# Altium schematic sheet TSAL.SchDoc(TSAL)
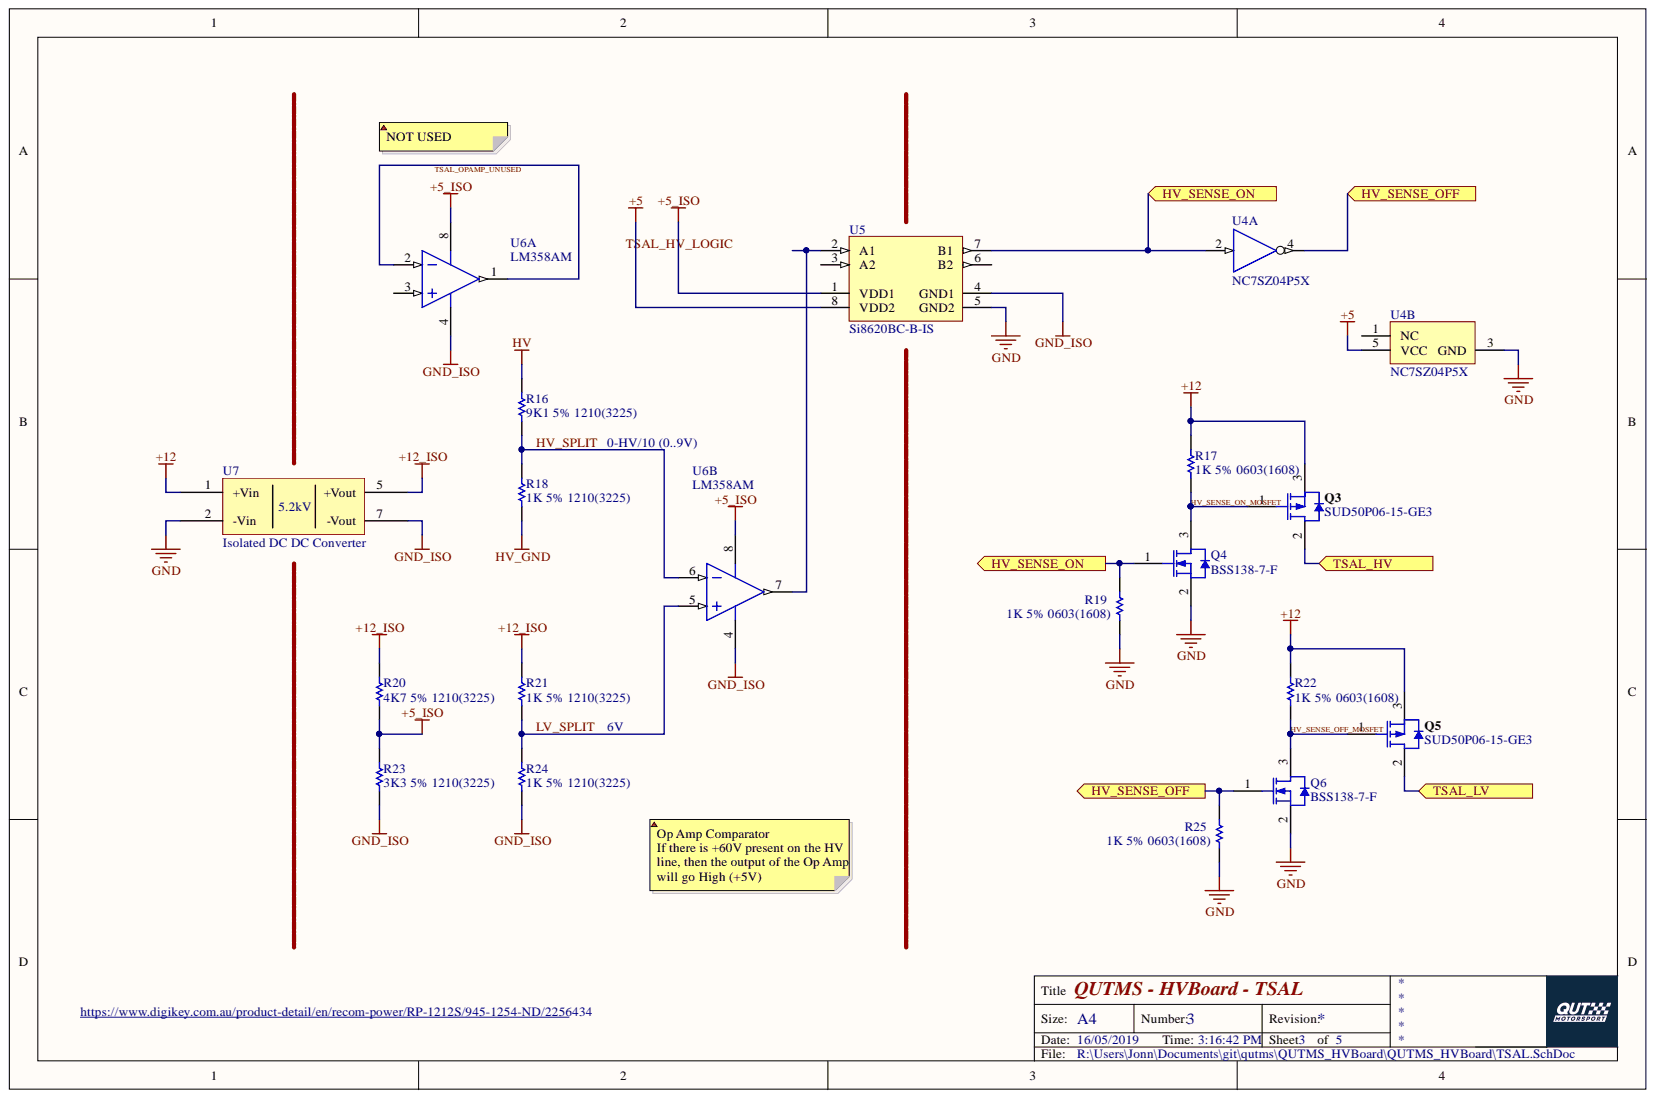
[diagram p0: TSAL.SchDoc]

## Parts
Q3  SUD50P06-15-GE3  [VSIL-TO-252AA-3_V]
Q4  BSS138-7-F  [DIOD-SOT23-3_V]
Q5  SUD50P06-15-GE3  [VSIL-TO-252AA-3_V]
Q6  BSS138-7-F  [DIOD-SOT23-3_V]
R16  9K1 5% 1210(3225)  [RESC1210(3225)_N]
R17  1K 5% 0603(1608)  [RESC0603(1608)_L]
R18  1K 5% 1210(3225)  [RESC1210(3225)_N]
R19  1K 5% 0603(1608)  [RESC0603(1608)_L]
R20  4K7 5% 1210(3225)  [RESC1210(3225)_N]
R21  1K 5% 1210(3225)  [RESC1210(3225)_N]
R22  1K 5% 0603(1608)  [RESC0603(1608)_L]
R23  3K3 5% 1210(3225)  [RESC1210(3225)_N]
R24  1K 5% 1210(3225)  [RESC1210(3225)_N]
R25  1K 5% 0603(1608)  [RESC0603(1608)_L]
U4  NC7SZ04P5X  [FAIR-SC70-5_V]  (parts A,B)
U5  Si8620BC-B-IS  [SLAB-SOIC-8-NB_M]
U6  LM358AM  [D0008A_N]  (parts A,B)
U7  Isolated DC DC Converter  [RP1212S]

## Ports
HV_SENSE_OFF, HV_SENSE_ON, TSAL_HV, TSAL_LV

## Nets
+5: U4.5(VCC) U5.8(VDD2)
+5_ISO: R20.1 R23.2 U5.1(VDD1) U6.8
+12: Q3.3 Q5.3 R17.2 R22.2 U7.1(+Vin)
+12_ISO: R20.2 R21.2 U7.5(+Vout)
GND: Q4.2 Q6.2 R19.1 R25.1 U4.3(GND) U5.5(GND2) U7.2(-Vin)
GND_ISO: R23.1 R24.1 U5.4(GND1) U6.4 U7.7(-Vout)
HV: R16.2
HV_GND: R18.1
HV_SENSE_OFF: Q6.1 R25.2 U4.4 ; port HV_SENSE_OFF
HV_SENSE_OFF_MOSFET: Q5.1 Q6.3 R22.1
HV_SENSE_ON: Q4.1 R19.2 U4.2 U5.7(B1) ; port HV_SENSE_ON
HV_SENSE_ON_MOSFET: Q3.1 Q4.3 R17.1
HV_SPLIT: R16.1 R18.2 U6.6
LV_SPLIT: R21.1 R24.2 U6.5
TSAL_HV: Q3.2 ; port TSAL_HV
TSAL_HV_LOGIC: U5.2(A1) U6.7
TSAL_LV: Q5.2 ; port TSAL_LV
TSAL_OPAMP_UNUSED: U6.1 U6.2

Not connected: U4 1(NC); U5 3(A2) 6(B2); U6 3

## Library data of the parts
Q3 Q5 = CMP-2000-05431-1: P-Channel (D-S) MOSFET, 30 V, -55 to 150 degC, 3-Pin TO-252, RoHS, Tape and Reel; Manufacturer: Vishay; Vgs - Gate-Source Voltage: 20V; Vds - Drain-Source Breakdown Voltage: -60V; Unit Weight: 0.050717oz; Typical Turn-On Delay Time: 15ns; Typical Turn-Off Delay Time: 175ns; Transistor Type: 1P-Channel; Rise Time: 70ns; Rds On - Drain-Source Resistance: 15mOhms; Pd - Power Dissipation: 2.5W; Number of Channels: 1Channel; Id - Continuous Drain Current: 50A; Configuration: Single; Channel Mode: Enhancement; Brand: VishaySemiconductors; Width: 6.22mm; Transistor Polarity: P-Channel; Tradename: TrenchFET; Technology: Si; Series: SUD; RoHS: True; Product Category: MOSFET; Packaging: Reel; Package URL: http://www.vishay.com/docs/89975/v15wl45c-m3.pdf; Package Reference: TO-252; Package Description: 3-Pin Surface Mount Device, Body 6.54 x 6.095 mm, Pitch 2.28 mm; Package / Case: TO-252AA-3; Mounting Style: SMD/SMT; Minimum Operating Temperature: -55C; Maximum Operating Temperature: +150C; Manufacturer URL: http://www.vishay.com/; Library Name: Altium Content Vault; Length: 6.73mm; Height: 2.39mm; Fall Time: 175ns; Factory Pack Quantity: 2000; Datasheet Version: Rev. C, 07/2013; Datasheet URL: https://datasheet.ciiva.com/4444/sud50p06-244530-4444327.pdf; Component Kind: Standard
Q4 Q6 = CMP-2000-04930-1: N-Channel Enhancement Mode Mosfet, 50 V, 0.2 A, -55 to 150 degC, 3-Pin SOT23, RoHS, Tape and Reel; Manufacturer: Diodes Inc; Vgs - Gate-Source Voltage: 20V; Vds - Drain-Source Breakdown Voltage: 50V; Unit Weight: 0.000282oz; Typical Turn-On Delay Time: 20ns; Transistor Type: 1N-Channel; Rds On - Drain-Source Resistance: 3.5Ohms; Pd - Power Dissipation: 300mW; Number of Channels: 1Channel; Id - Continuous Drain Current: 200mA; Configuration: Single; Brand: DiodesIncorporated; Width: 1.3mm; Typical Turn-Off Delay Time: 20ns; Transistor Polarity: N-Channel; Technology: Si; Series: BSS138; RoHS: True; Product Category: MOSFET; Product: MOSFETSmallSignal; Packaging: Reel; Package Reference: SOT23-3; Package Description: 3-Pin Small Outline Transistor, Body 2.9 x 1.3 mm, Pitch 0.96 mm; Package / Case: SOT-23-3; Mounting Style: SMD/SMT; Minimum Operating Temperature: -55C; Maximum Operating Temperature: +150C; Manufacturer URL: http://www.diodes.com/; Library Name: Altium Content Vault; Length: 2.9mm; Height: 1mm; Factory Pack Quantity: 3000; Datasheet Version: Rev. 20-2, 11/2013; Datasheet URL: https://datasheet.ciiva.com/8115/ds30144-8115344.pdf; Component Kind: Standard; Channel Mode: Enhancement
R16 = CMP-1015-00097-1: 9K1 0.5W 5% 1210 (3225 Metric)  SMD; Value: 9100.00 Ohm; Case-Metric: 3225; Case-EIA: 1210; Tolerance: 5 %; Technology: SMT; Power: 0.5 W; Library Name: Altium Content Vault; Component Kind: Standard
R17 R19 R22 R25 = CMP-1012-00074-1: 1K 0.1W 5% 0603 (1608 Metric)  SMD; Value: 1000.00 Ohm; Case-Metric: 1608; Case-EIA: 0603; Tolerance: 5 %; Technology: SMT; Power: 0.1 W; Library Name: Altium Content Vault; Component Kind: Standard
R18 R21 R24 = CMP-1015-00074-1: 1K 0.5W 5% 1210 (3225 Metric)  SMD; Value: 1000.00 Ohm; Case-Metric: 3225; Case-EIA: 1210; Tolerance: 5 %; Technology: SMT; Power: 0.5 W; Library Name: Altium Content Vault; Component Kind: Standard
R20 = CMP-1015-00090-1: 4K7 0.5W 5% 1210 (3225 Metric)  SMD; Value: 4700.00 Ohm; Case-Metric: 3225; Case-EIA: 1210; Tolerance: 5 %; Technology: SMT; Power: 0.5 W; Library Name: Altium Content Vault; Component Kind: Standard
R23 = CMP-1015-00086-1: 3K3 0.5W 5% 1210 (3225 Metric)  SMD; Value: 3300.00 Ohm; Case-Metric: 3225; Case-EIA: 1210; Tolerance: 5 %; Technology: SMT; Power: 0.5 W; Library Name: Altium Content Vault; Component Kind: Standard
U4 = CMP-2000-06814-1: TinyLogic(R) UHS Inverter, 32 mA, 1.65 to 5.5 V, -40 to 85 degC, 5-Pin SOT23 (SC70), RoHS, Tape and Reel; Manufacturer: FairchildSemiconductor; Typical Operating Supply Voltage: 1.8; Rad Hard: No; Propagation Delay Test Condition: 50pF; Number of Elements per Chip: 1; Maximum Propagation Delay Time @ Maximum CL: 5@3.3V; Maximum Low Level Output Current: 32mA; Maximum High Level Output Current: -32mA; Logic Family: TinyLogicUHS; Fabrication Technology: CMOS; Packaging: Tape&Reel; Package URL: https://www.fairchildsemi.com/package-drawings/MA/MAA05A.pdf; Package Reference: SC70-5; Package Description: 5-Pin Small Outline Transistor, Body 2 x 1.25 mm, Pitch 0.65 mm; Package: 5SC-70; Operating Temperature: -40to85°C; Mounting Technology: SurfaceMount; Manufacturer URL: https://www.fairchildsemi.com/; Logic Function: Inverter; Library Name: Altium Content Vault; Datasheet Version: Rev. 1.0.5, 02/2011; Datasheet URL: https://www.fairchildsemi.com/datasheets/NC/NC7SZ04.pdf; Component Kind: Standard; Category: InverterSchmittTrigger
U5 = CMP-0512-00372-1: Low-Power Single and Dual-Channel Digital Isolator, 150 Mbps, 3.75 kVRMS Isolation Rating, -40 to 125 degC, 8-pin SOIC; Manufacturer: Silicon Labs; Sub-Family: Isolators; Maximum Propagation Delay (ns): 13; Maximum Data Rate (Mbps): 150; Isolation Rating (kV): 3.75; Enable Control: FALSE; Channel Type: Unidirectional; AEC-Q100: TRUE; PartNumber: Si8620BC-B-IS; PackageReference: SOIC-8-NB; PackageDescription: 8-Pin Narrow Body Plastic Small Outline, Body 4.9 x 3.9 mm, Pitch 1.27 mm; Mounting Technology: Surface Mount; Library Name: Altium Content Vault; DatasheetVersion: Rev. 1.3; ComponentLink3URL: http://www.silabs.com/Support%20Documents/TechnicalDocs/Si8220-21.pdf; ComponentLink3Description: Package Specification; ComponentLink2URL: http://www.silabs.com/Support%20Documents/TechnicalDocs/Si861x-2x.pdf; ComponentLink2Description: Datasheet; ComponentLink1URL: http://www.silabs.com/; ComponentLink1Description: Manufacturer URL; Component Kind: Standard; 10kV surge: FALSE
U6 = CMP-0055-00796-3: Low Power Dual Operational Amplifier, 8-pin Narrow SOIC; Manufacturer: Texas Instruments; PowerWise: N; PackageTopMarking: NSZXTT*LM*358AM; CompType: Amplifiers; RoHS: N; PinCount: 8; Package Reference: D0008A; PackageDescription: SOIC Narrow, 4.9 x 3.9 x 1.45mm, 8 Lead, 1.27mm Pitch; Library Name: Altium Content Vault; ComponentLink1URL: http://www.ti.com/general/docs/lit/getliterature.tsp?genericPartNumber=LM358-N&fileType=pdf; ComponentLink1Description: Datasheet URL; Component Kind: Standard; Code_JEDEC: MS-012-AA; Code_IPC: SOIC127P600-8
U7 = RP-1212S: ComponentLink2URL: https://www.recom-power.com/pdf/Econoline/RP.pdf; ComponentLink2Description: Datasheet; ComponentLink1URL: https://www.digikey.com.au/product-detail/en/recom-power/RP-1212S/945-1254-ND/2256434; ComponentLink1Description: DigiKey; Component Kind: Standard; Library Name: QUTMS_IsolatedPowerSupply.SchLib
